# Altium schematic sheet Sch-QWA17_HDMI.SchDoc(Sch-QWA17_HDMI)
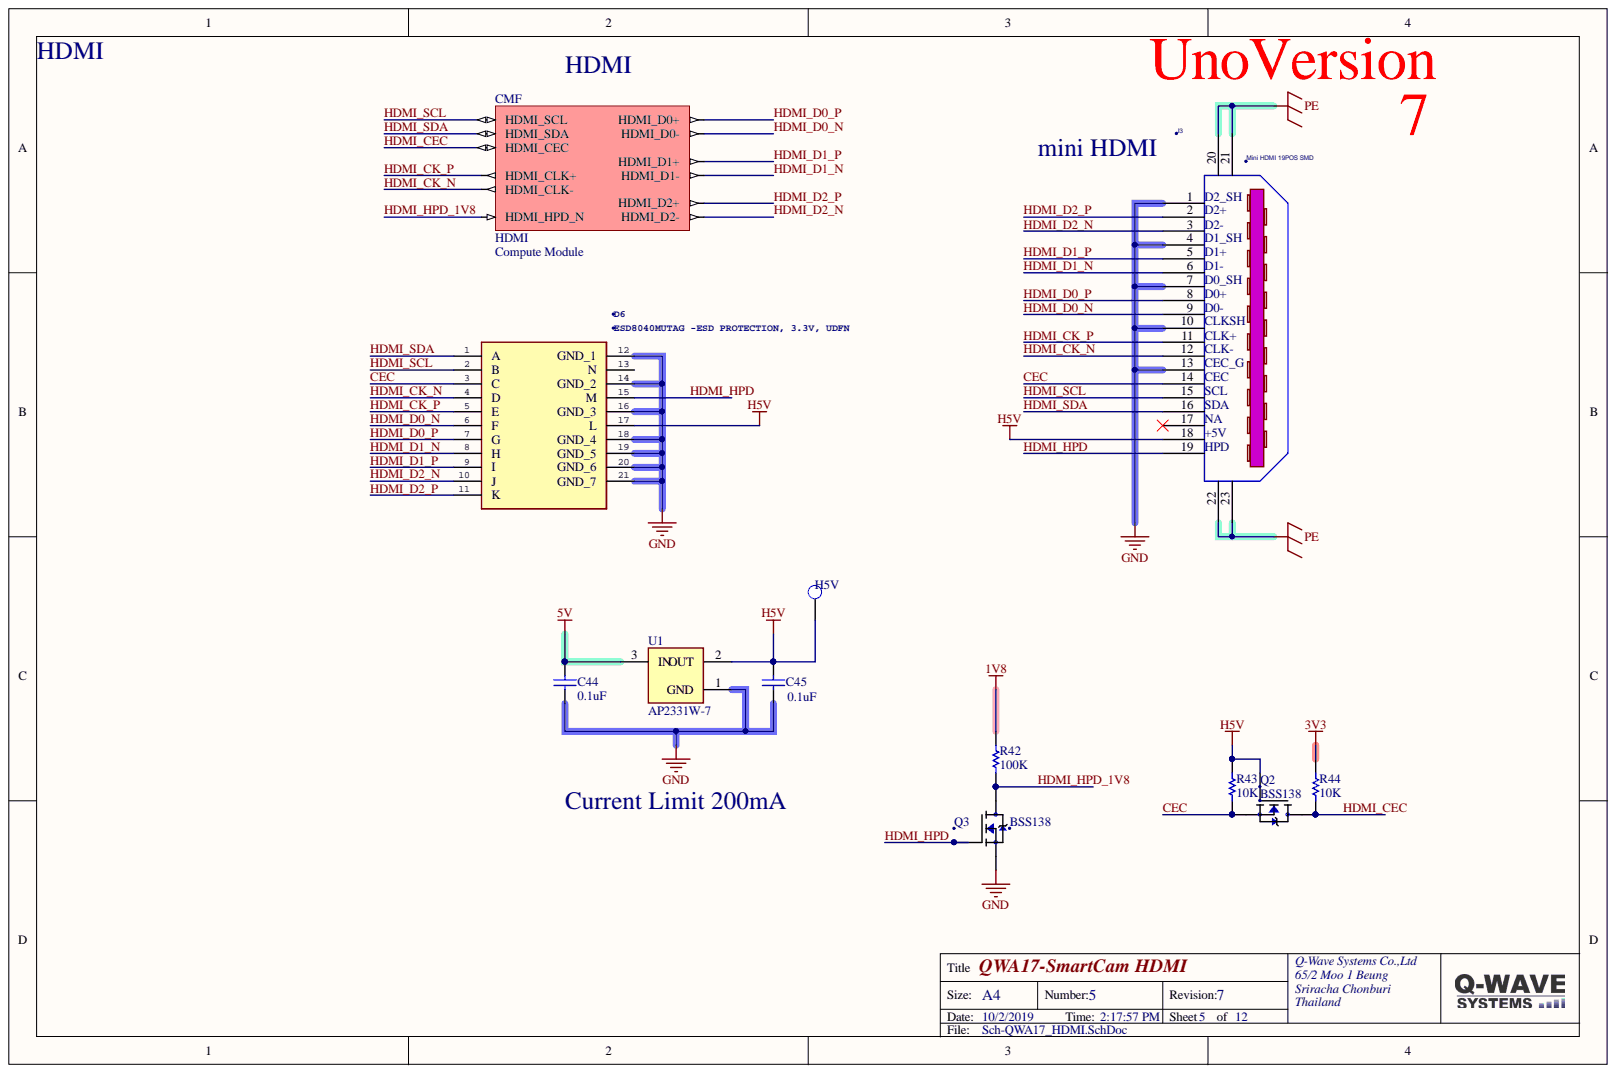
[diagram p0: Sch-QWA17_HDMI.SchDoc]

## Parts
C44  0.1uF  [CAP_0603]
C45  0.1uF  [CAP_0603]
CM  Compute Module  [1473005-4]  (part F)
D6  ESD8040MUTAG -ESD PROTECTION, 3.3V, UDFN  [ESD8040MUTAG]
H5V  H5V  [TEST_POINT]
J3  Mini HDMI 19POS SMD  [685119136923]
Q2  BSS138  [SOT-23-3]
Q3  BSS138  [SOT-23-3]
R42  100K  [RES_0603]
R43  10K  [RES_0603]
R44  10K  [RES_0603]
U1  Part Number: AP2331W-7  [Diodes_AP2331W-7_0]  (hidden comment: IC_Diodes_AP2331W-7_eec)

## Nets
1V8: R42.1
3V3: R44.1
5V: C44.2 U1.3
GND: C44.1 C45.1 D6.12(GND_1) D6.14(GND_2) D6.16(GND_3) D6.18(GND_4) D6.19(GND_5) D6.20(GND_6) D6.21(GND_7) J3.1 J3.4 J3.7(D0_SH) J3.10 J3.13 Q3.2 U1.1
H5V: C45.2 D6.17(L) H5V.1 J3.18 Q2.1 R43.1 U1.2
PE: J3.20 J3.21 J3.22 J3.23
CEC: D6.3(C) J3.14 Q2.2 R43.2
HDMI_CEC: CM.171(HDMI_CEC) Q2.3 R44.2
HDMI_CK_N: CM.111(HDMI_CLK-) D6.4(D) J3.12
HDMI_CK_P: CM.113(HDMI_CLK+) D6.5(E) J3.11
HDMI_D0_N: CM.117(HDMI_D0-) D6.6(F) J3.9
HDMI_D0_P: CM.119(HDMI_D0+) D6.7(G) J3.8(D0+)
HDMI_D1_N: CM.123(HDMI_D1-) D6.8(H) J3.6
HDMI_D1_P: CM.125(HDMI_D1+) D6.9(I) J3.5
HDMI_D2_N: CM.129(HDMI_D2-) D6.10(J) J3.3(D2-)
HDMI_D2_P: CM.131(HDMI_D2+) D6.11(K) J3.2
HDMI_HPD: D6.15(M) J3.19 Q3.1
HDMI_HPD_1V8: CM.88(HDMI_HPD_N) Q3.3 R42.2
HDMI_SCL: CM.175(HDMI_SCL) D6.2(B) J3.15
HDMI_SDA: CM.173(HDMI_SDA) D6.1(A) J3.16

Not connected: D6 13(N); J3 17

## Library data of the parts
C44 C45 = Cap Semi: 0.1µF ±5% 50V Ceramic Capacitor X7R 0603; MFG: KEMET; MFG P/N: C0603C104J5RACTU; Component Kind: Standard; Library Name: Miscellaneous Devices.IntLib; Simulation: CAP
CM = Compute Module: Raspberry Pi Compute Module; Component Kind: Standard; Library Name: Compute Module.SchLib
D6 = ESD8040MUTAG: Manufacturer_Name: ON Semiconductor; GateName_1: G$1; Component Kind: Standard; Description: ESD8040MUTAG -ESD PROTECTION, 3.3V, UDFN; DeviceSetName: ESD8040MUTAG; Height: 0.55mm; Library Name: ESD8040MUTAG.SCHLIB; LibraryName: ESD8040MUTAG; Manufacturer_Part_Number: ESD8040MUTAG; Mouser_Part_Number: 863-ESD8040MUTAG; SymbolName_1: ESD8040MUTAG
H5V = TEST_POINT: Test Point; Component Kind: Standard; Library Name: Misc.SCHLIB
J3 = 685119136923: mini HDMI 19POS SMD 685119136923; Component Kind: Standard; Library Name: 685119136923.SCHLIB
Q2 Q3 = BSS138: N-Channel 50V 220mA (Ta) 360mW (Ta) Surface Mount SOT-23-3; MFG: ON Semiconductor; Availability: Unavailable; Component Kind: Standard; MFG P/N: BSS138; Package: SOT-23 Diodes Inc.; Price: 0.21 USD; Supplier1: ES; Supplier_Part_Number: 0016-0377-1; Supplier_Purchase_URL: https://www.es.co.th/detail.asp?Prod=001603771; Library Name: EspVIEW.IntLib
R42-R44 = Res Semi: Resistor 0603; Component Kind: Standard; Library Name: Miscellaneous Devices.IntLib; Simulation: RES
U1 = IC_Diodes_AP2331W-7_eec: IC LOAD SWITCH SC59; Manufacturer: Diodes; Component Kind: Standard; ComponentLink1Description: Upverter; ComponentLink1URL: https://upverter.com/upn/revision/7e2e43c6fc93a657/; ComponentLink2Description: Datasheet; ComponentLink2URL: http://www.diodes.com/_files/datasheets/AP2331.pdf; Digikey Description: IC LOAD SWITCH SC59; Digikey Part Number: AP2331W-7DICT-ND; helpURL: https://upverter.com/upn/revision/7e2e43c6fc93a657/; Lead Free: yes; Library Name: IC_Diodes_AP2331W-7_eec.SchLib; Mouser Part Number: 621-AP2331W-7; Package: SC-59; Price: 0.244736178545; RoHS: TRUE; Supplier 1: Digi-Key; Supplier 2: Mouser; Supplier Part Number 1: AP2331W-7DICT-ND; Supplier Part Number 2: 621-AP2331W-7; Temperature: -40°C to +85°C; Temperature Range High: +85°C; Temperature Range Low: -40°C
